# Altium schematic sheet sample_board.SchDoc(sample_board)
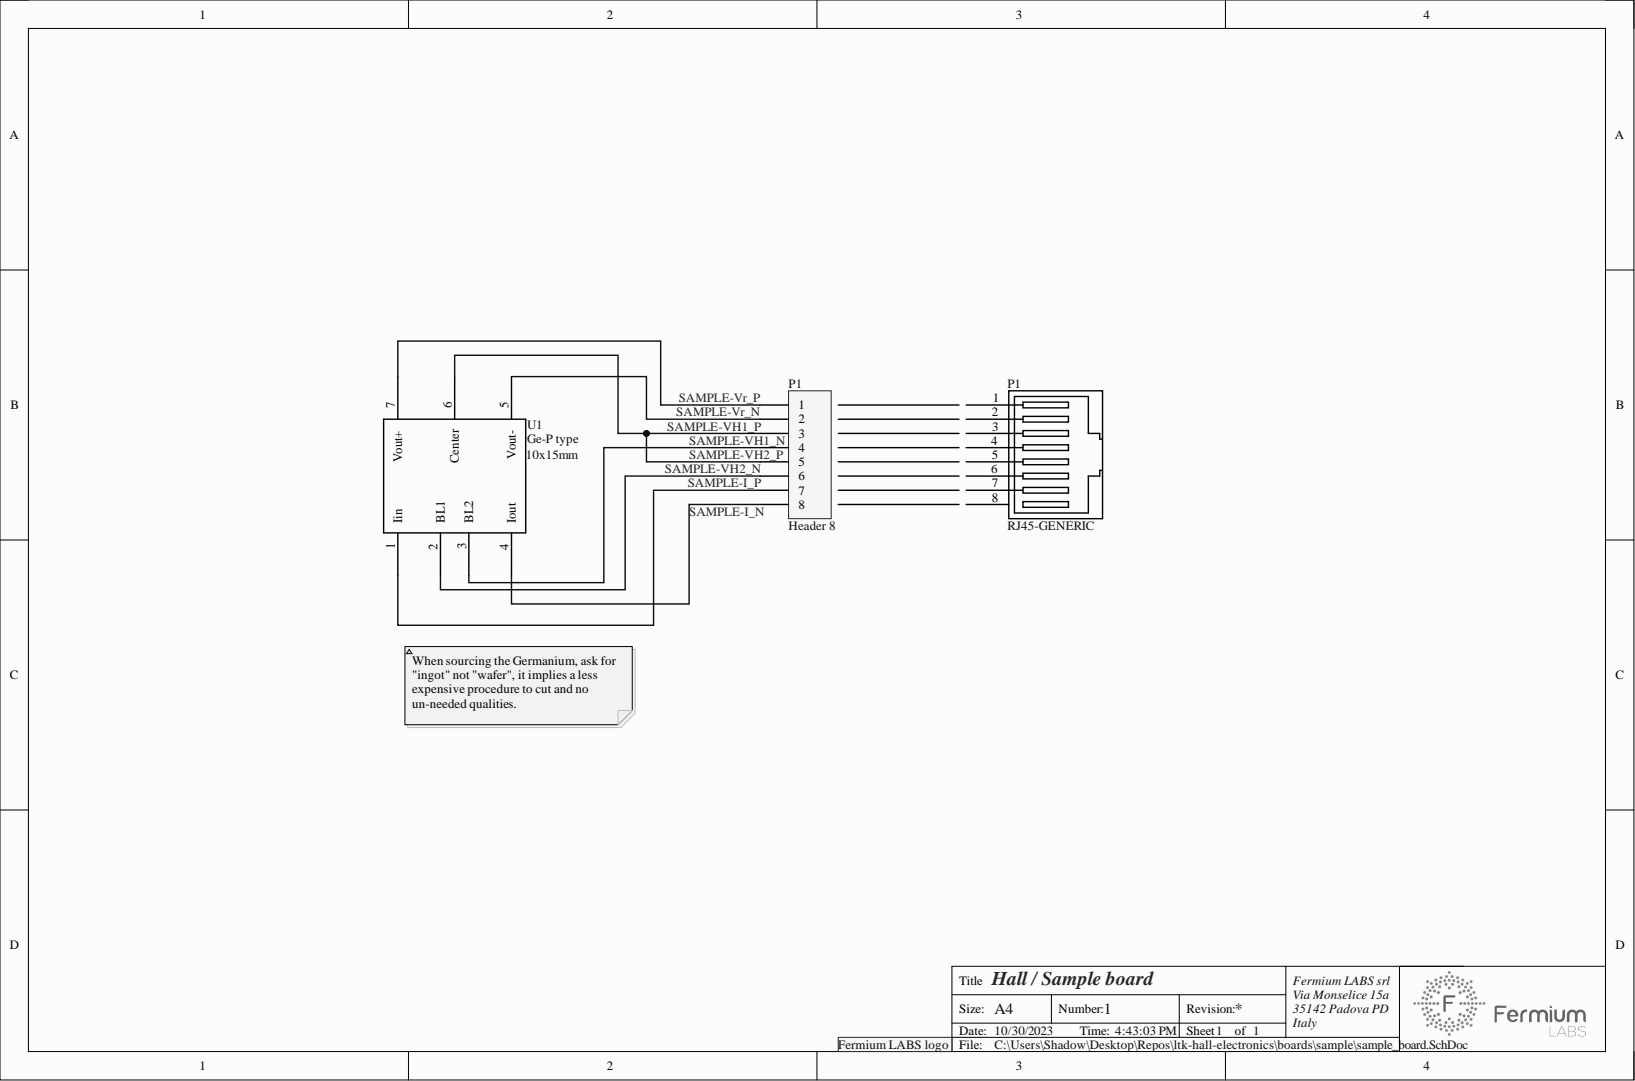
[diagram p0: sample_board.SchDoc]

## Parts
logo  fermiumlabs-logo  [frm-med]  (value not drawn)  (no BOM)
P1  Header 8  [Edge Connector]  (no BOM)
U1  Ge-P type  Dimensions: 10x15mm  [Germanium Sample]

## Nets
SAMPLE-I_N: P1.8 U1.4(Iout)
SAMPLE-I_P: P1.7 U1.1(Iin)
SAMPLE-VH1_N: P1.4 U1.3(BL2)
SAMPLE-VH1_P: P1.3 P1.5 U1.6(Center)
SAMPLE-VH2_N: P1.6 U1.2(BL1)
SAMPLE-Vr_N: P1.2 U1.5(Vout-)
SAMPLE-Vr_P: P1.1 U1.7(Vout+)

## Library data of the parts
P1 = Header 8: Header, 8-Pin; LatestRevisionDate: 17-Jul-2002; LatestRevisionNote: Re-released for DXP Platform.; Library Name: Miscellaneous Connectors.IntLib; Pads: N/A; Signal Integrity: Connector; Publisher: Altium Limited
U1 = Germanium Sample: Sample germanium; Manufacturer: Changsha Santech Materials; Component Kind: Standard; Doping: Ge-P type; Library Name: custom_components.SchLib; Pads: N/A; Supplier 1: Changsha Santech Materials; Supplier Part Number 1: ge-p-sample
logo = fermiumlabs-logo: Library Name: custom_components.SchLib
